# Altium schematic sheet THINK.SCHDOC
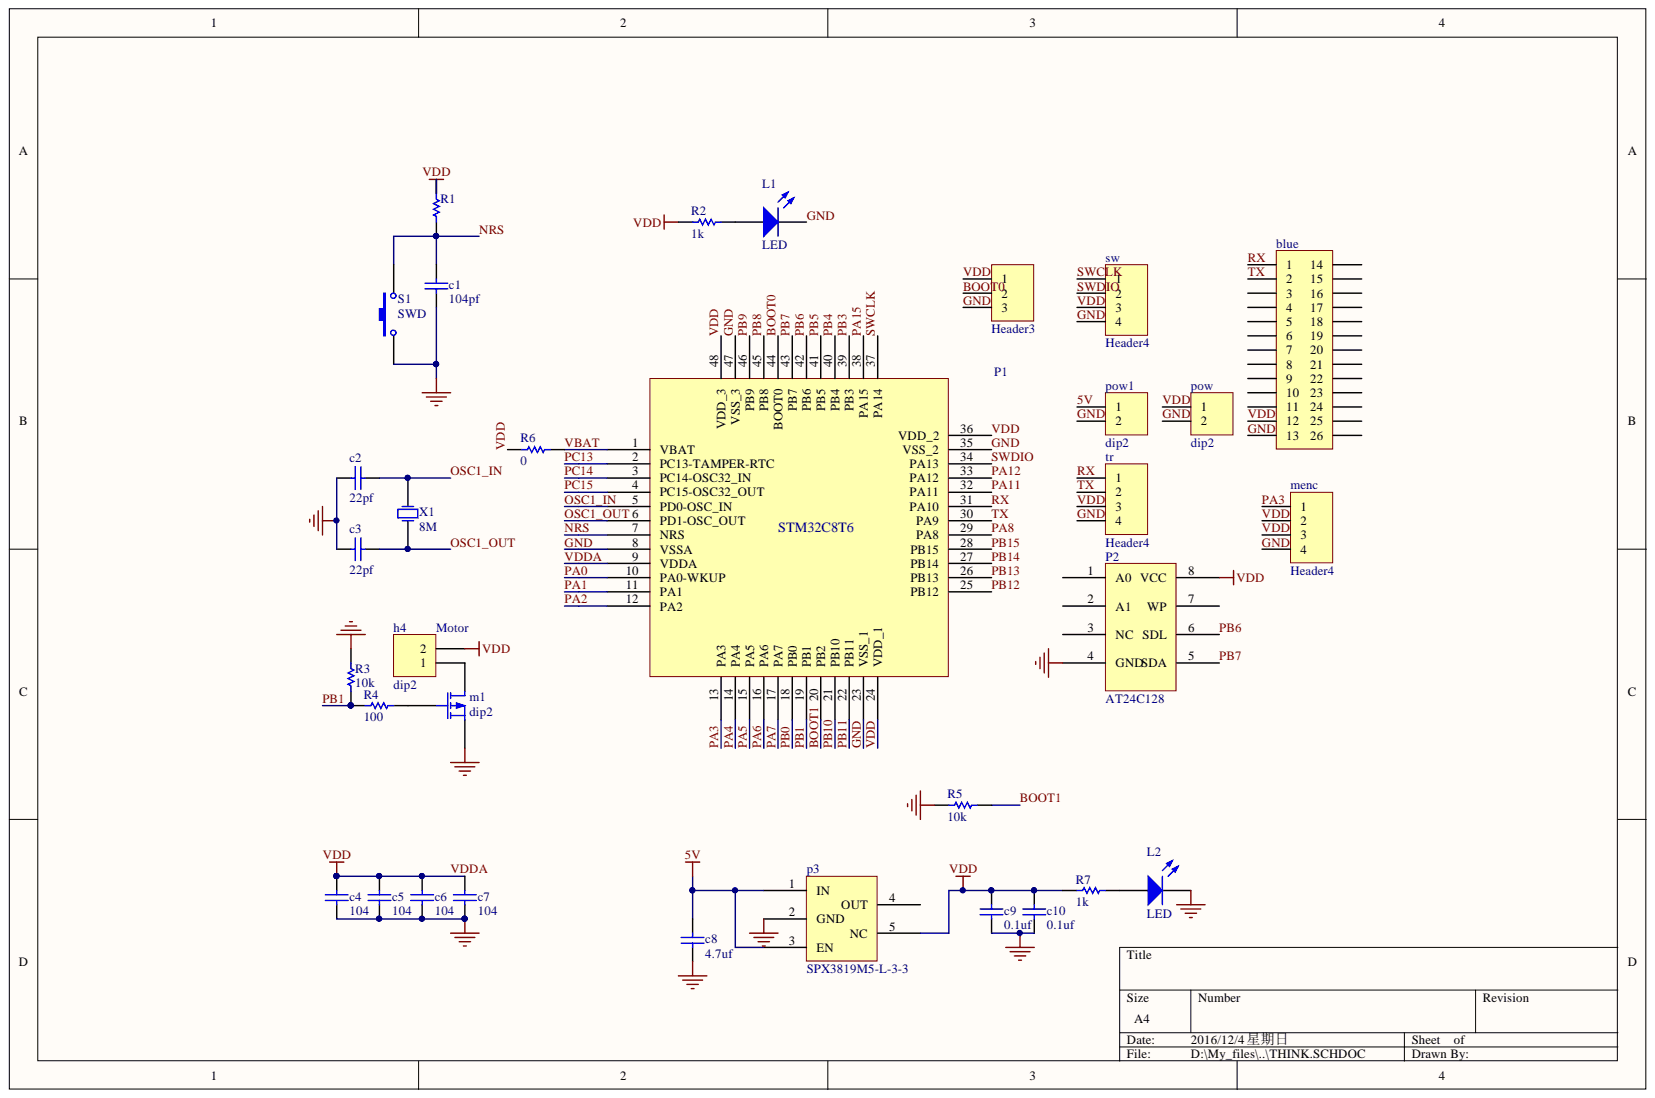
[diagram p0: THINK.SCHDOC]

## Parts
b0  Header3  [Header3]
blue  blue  [blue]
c1  104pf  [Res_0603]
c2  22pf  [Res_0603]
c3  22pf  [Res_0603]
c4  104  [Res_0603]
c5  104  [Res_0603]
c6  104  [Res_0603]
c7  104  [Res_0603]
c8  4.7uf  [Res_0603]
c9  0.1uf  [Res_0603]
c10  0.1uf  [Res_0603]
h4  dip2  [Hearder2]
L1  LED  [CAP0603]
L2  LED  [CAP0603]
m1  dip2  [Mos]
menc  Header4  [Header4]
P1  STM32C8T6  [stm32_c8t6]
P2  AT24C128  [AT24C128]
p3  SPX3819M5-L-3-3  [SPX3819M5-L-3-3]
pow  dip2  [Hearder2]
pow1  dip2  [Hearder2]
R1  100k  [Res_0603]  (value not drawn)
R2  1k  [Res_0603]
R3  10k  [Res_0603]
R4  100  [Res_0603]
R5  10k  [Res_0603]
R6  0  [Res_0603]
R7  1k  [Res_0603]
S1  SWD  [SWD]
sw  Header4  [Header4]
tr  Header4  [Header4]
X1  8M  [jingzhen]

## Nets
5V: c8.2 p3.1(IN) p3.3(EN) pow1.1
BOOT0: b0.2 P1.44(BOOT0)
BOOT1: P1.20(PB2) R5.2
GND: b0.3 blue.13 c1.1 c2.1 c3.1 c4.1 c5.1 c6.1 c7.1 c8.1 c9.1 c10.1 L1.2 L2.2 m1.3 menc.4 P1.8(VSSA) P1.23(VSS_1) P1.35(VSS_2) P1.47(VSS_3) P2.4(GND) p3.2(GND) pow.2 pow1.2 R3.1 R5.1 S1.1 sw.4 tr.4
NRS: c1.2 P1.7(NRS) R1.2 S1.2
OSC1_IN: c2.2 P1.5(PD0-OSC_IN) X1.3
OSC1_OUT: c3.2 P1.6(PD1-OSC_OUT) X1.1
PA0: P1.10(PA0-WKUP)
PA1: P1.11(PA1)
PA2: P1.12(PA2)
PA3: menc.1 P1.13(PA3)
PA4: P1.14(PA4)
PA5: P1.15(PA5)
PA6: P1.16(PA6)
PA7: P1.17(PA7)
PA8: P1.29(PA8)
PA11: P1.32(PA11)
PA12: P1.33(PA12)
PA15: P1.38(PA15)
PB0: P1.18(PB0)
PB1: P1.19(PB1) R3.2 R4.1
PB3: P1.39(PB3)
PB4: P1.40(PB4)
PB5: P1.41(PB5)
PB6: P1.42(PB6) P2.6(SDL)
PB7: P1.43(PB7) P2.5(SDA)
PB8: P1.45(PB8)
PB9: P1.46(PB9)
PB10: P1.21(PB10)
PB11: P1.22(PB11)
PB12: P1.25(PB12)
PB13: P1.26(PB13)
PB14: P1.27(PB14)
PB15: P1.28(PB15)
PC13: P1.2(PC13-TAMPER-RTC)
PC14: P1.3(PC14-OSC32_IN)
PC15: P1.4(PC15-OSC32_OUT)
RX: blue.1 P1.31(PA10) tr.1
SWCLK: P1.37(PA14) sw.1
SWDIO: P1.34(PA13) sw.2
TX: blue.2 P1.30(PA9) tr.2
VBAT: P1.1(VBAT) R6.2
VDD: b0.1 blue.12 c4.2 c5.2 c6.2 c7.2 c9.2 c10.2 h4.2 menc.2 menc.3 P1.9(VDDA) P1.24(VDD_1) P1.36(VDD_2) P1.48(VDD_3) P2.8(VCC) p3.5(NC) pow.1 R1.1 R2.1 R6.1 R7.1 sw.3 tr.3
Neth4_1: h4.1 m1.1
NetL1_1: L1.1 R2.2
NetL2_1: L2.1 R7.2
Netm1_2: m1.2 R4.2

Not connected: blue 3 4 5 6 7 8 9 10 11 14 15 16 17 18 19 20 21 22 23 24 25 26; P2 1(A0) 2(A1) 3(NC) 7(WP); p3 4(OUT)

## Library data of the parts
c1-c10 = Cap_no: Component Kind: Standard
h4 pow pow1 = Header2: Component Kind: Standard
m1 = Mos: Component Kind: Standard
R1-R7 = RES_0603: Component Kind: Standard
  R6: Library Name: Sch_Think.SchLib
X1 = jingzhen: Component Kind: Standard
b0 = Header3: Component Kind: Standard
blue = blue: Component Kind: Standard
L1 L2 = LED: Component Kind: Standard
menc sw tr = Header4: Component Kind: Standard
P1 = STM32C8T6: Component Kind: Standard
P2 = AT24C128: Component Kind: Standard
p3 = SPX3819M5-L-3-3: Component Kind: Standard
S1 = SWD: Component Kind: Standard
